# Altium schematic sheet CPLD.SchDoc(CPLD)
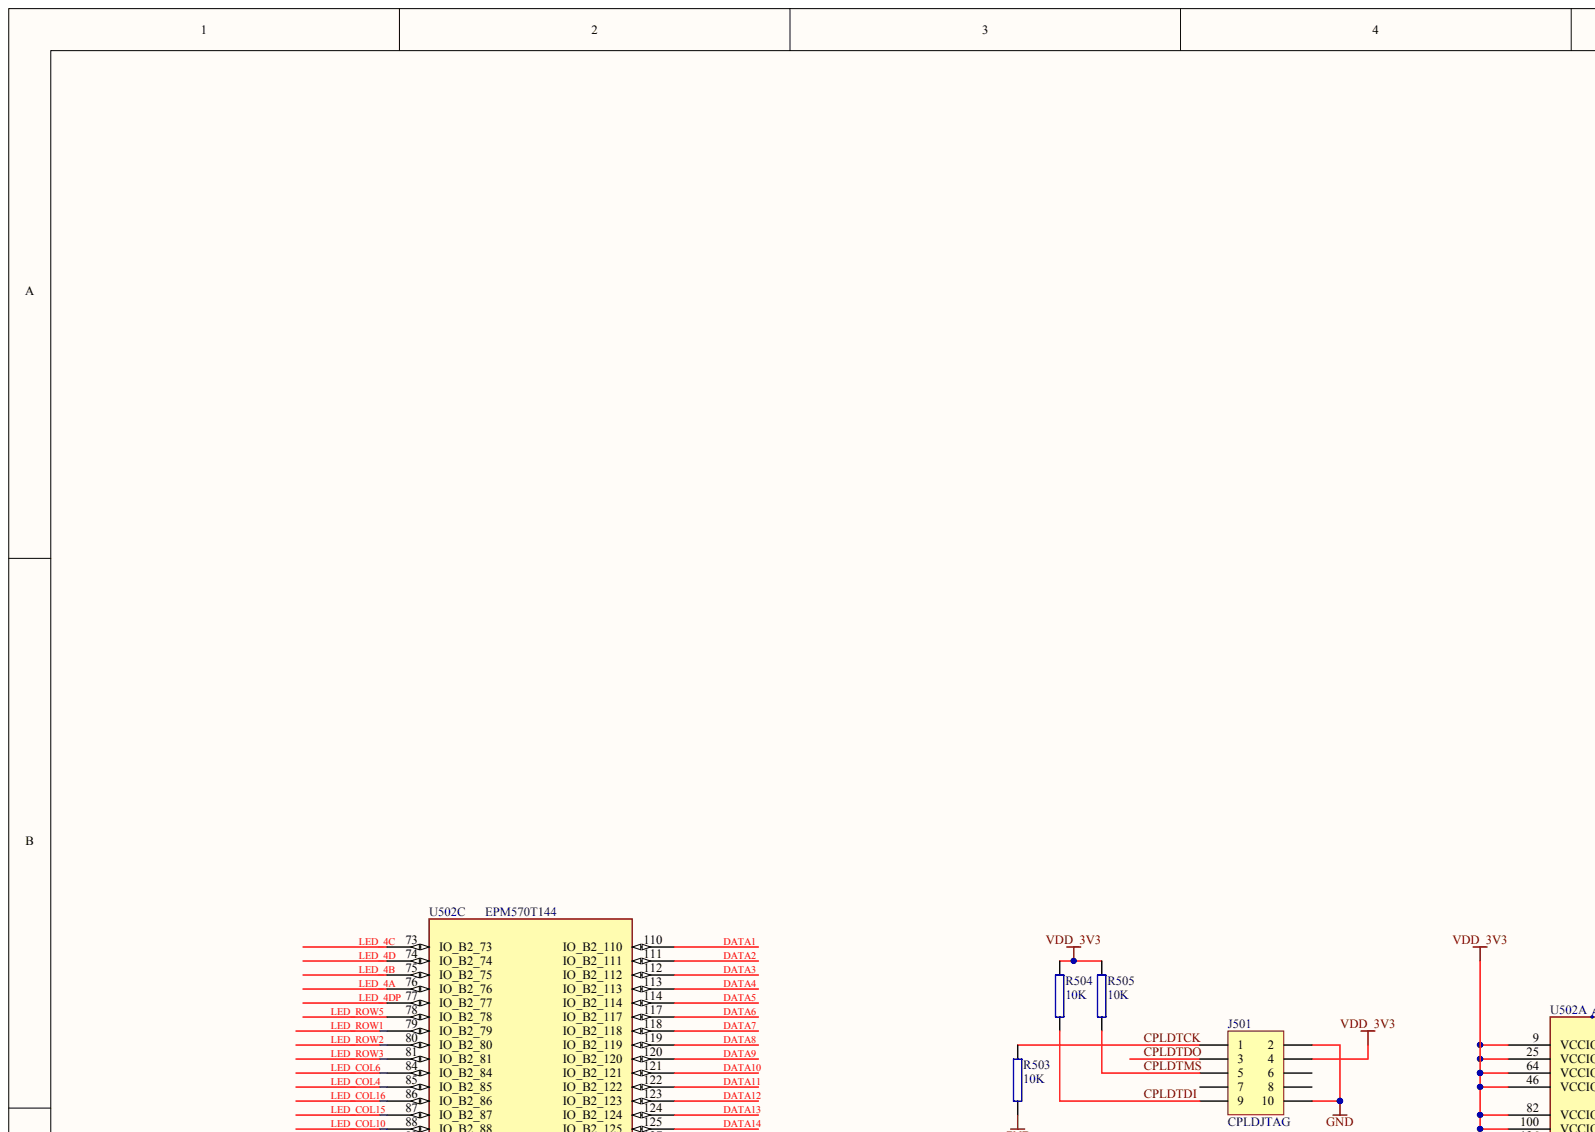
[diagram p0: CPLD.SchDoc, top left]
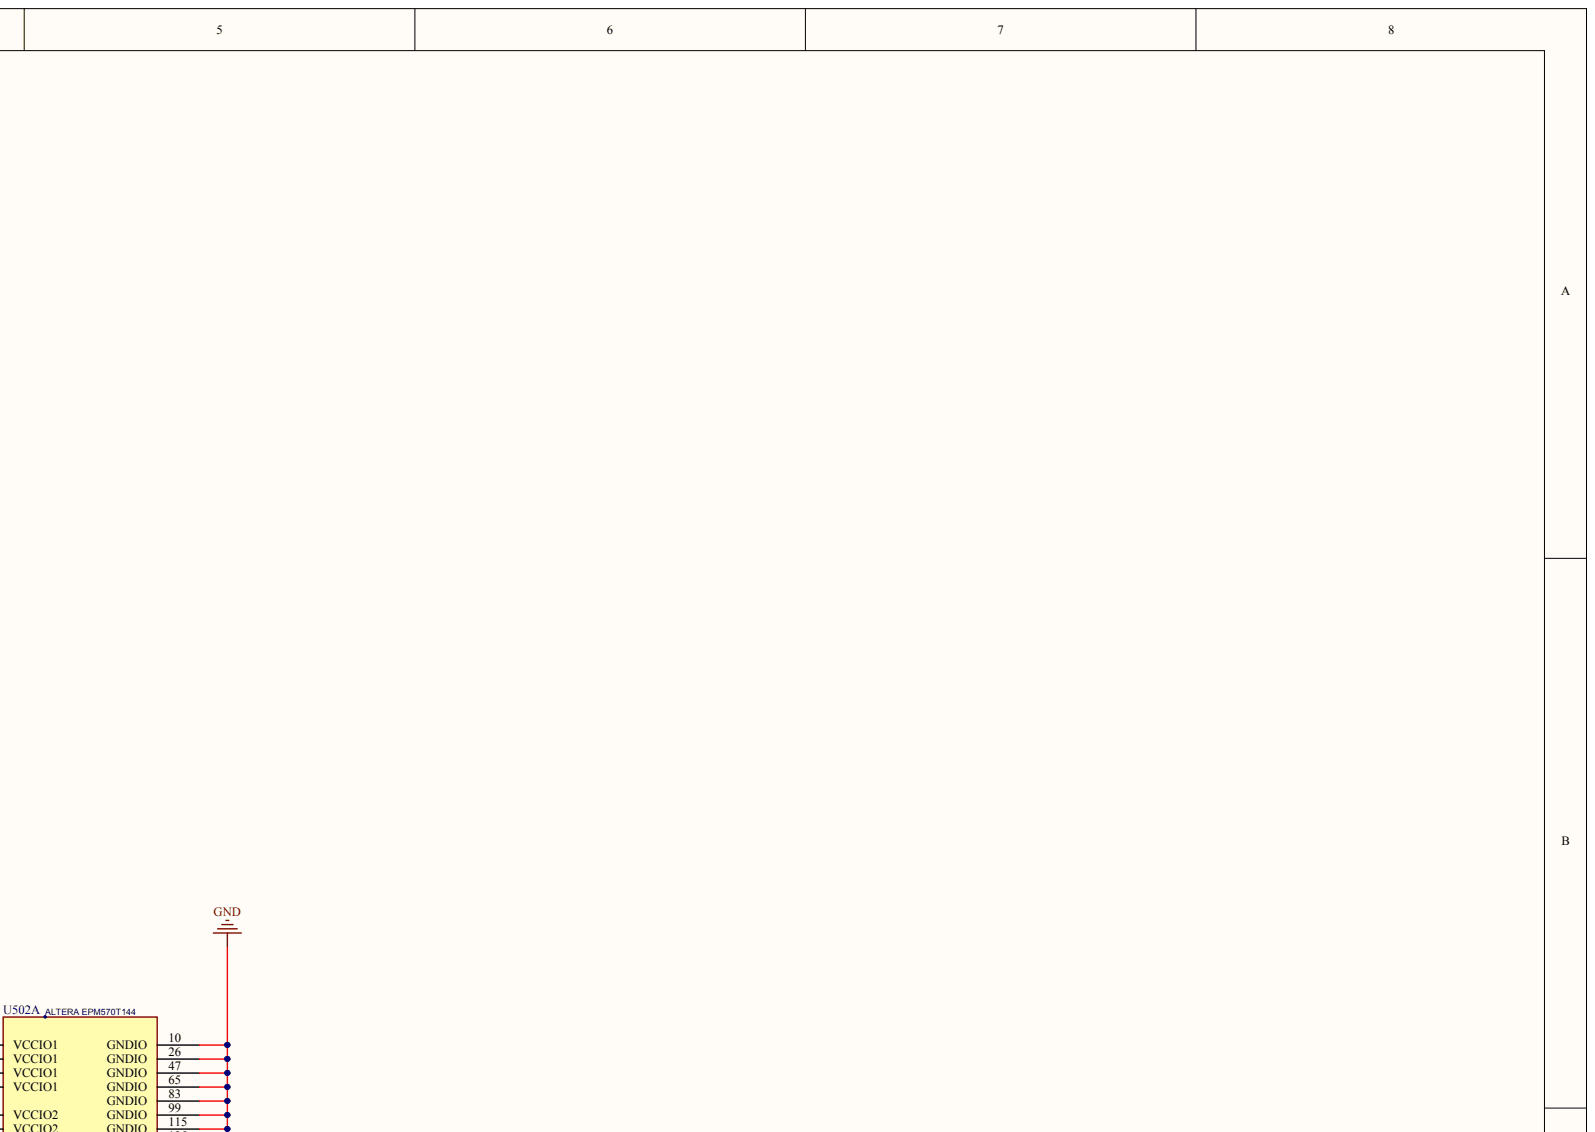
[diagram p1: CPLD.SchDoc, top right]
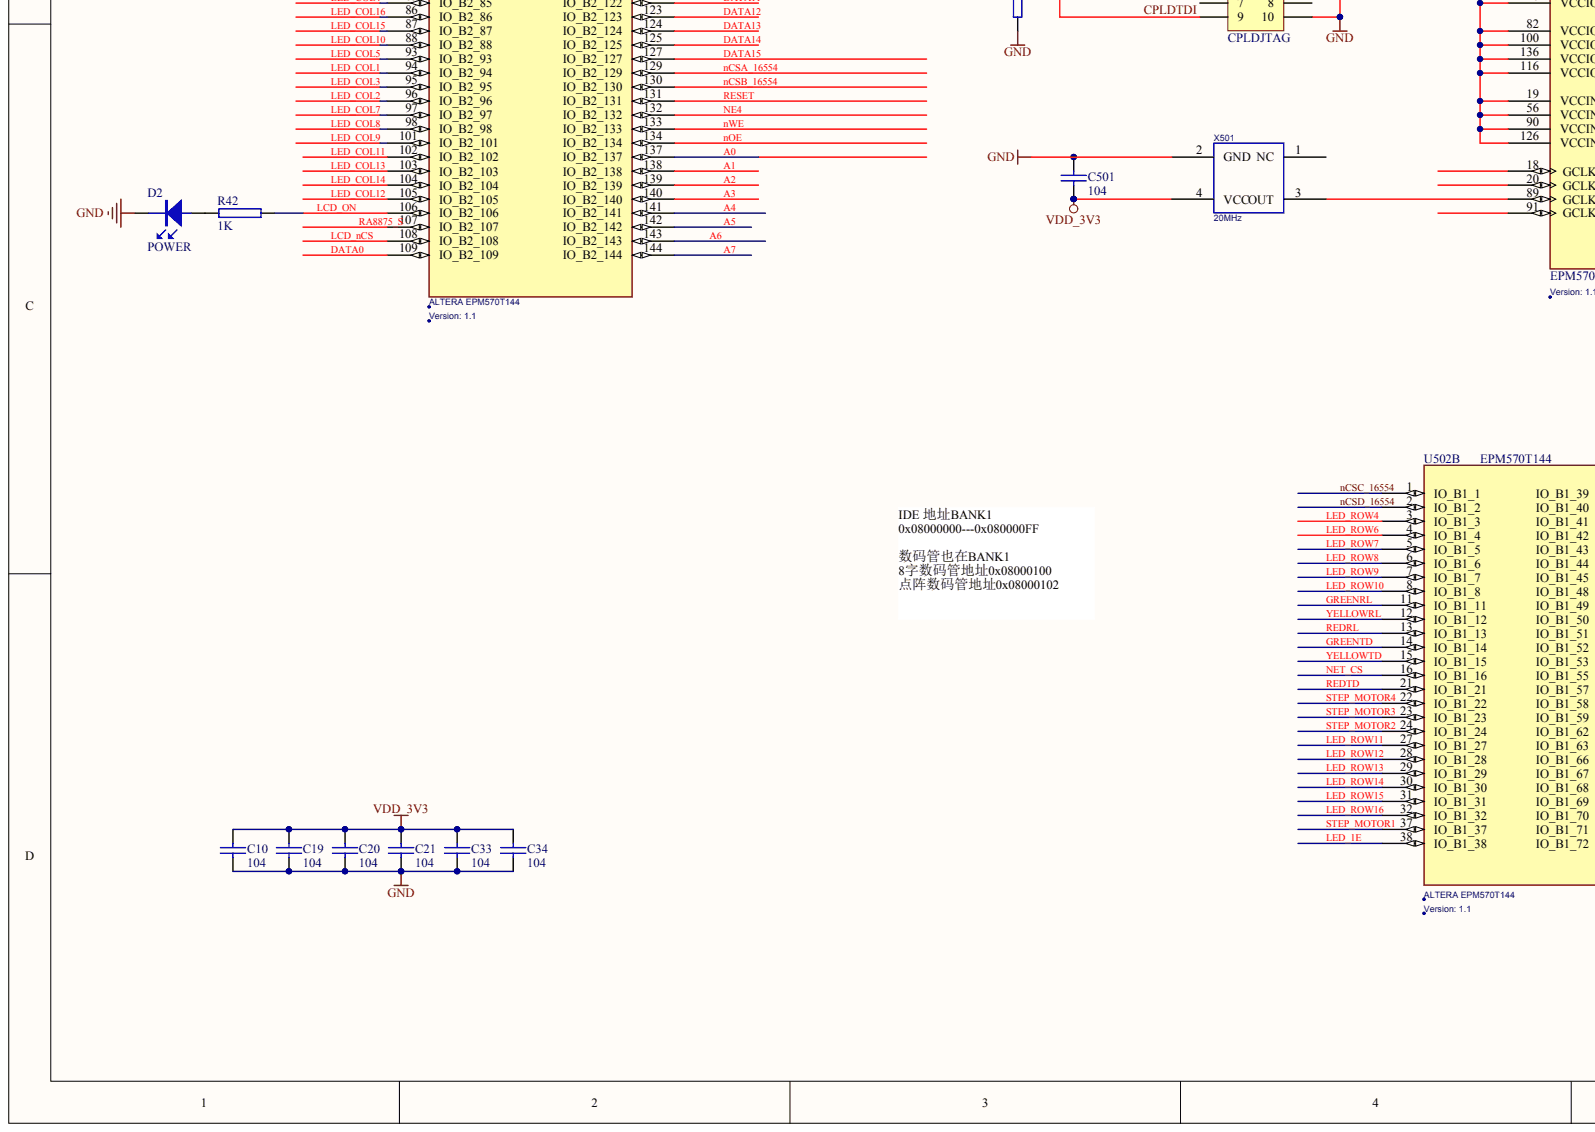
[diagram p2: CPLD.SchDoc, bottom left]
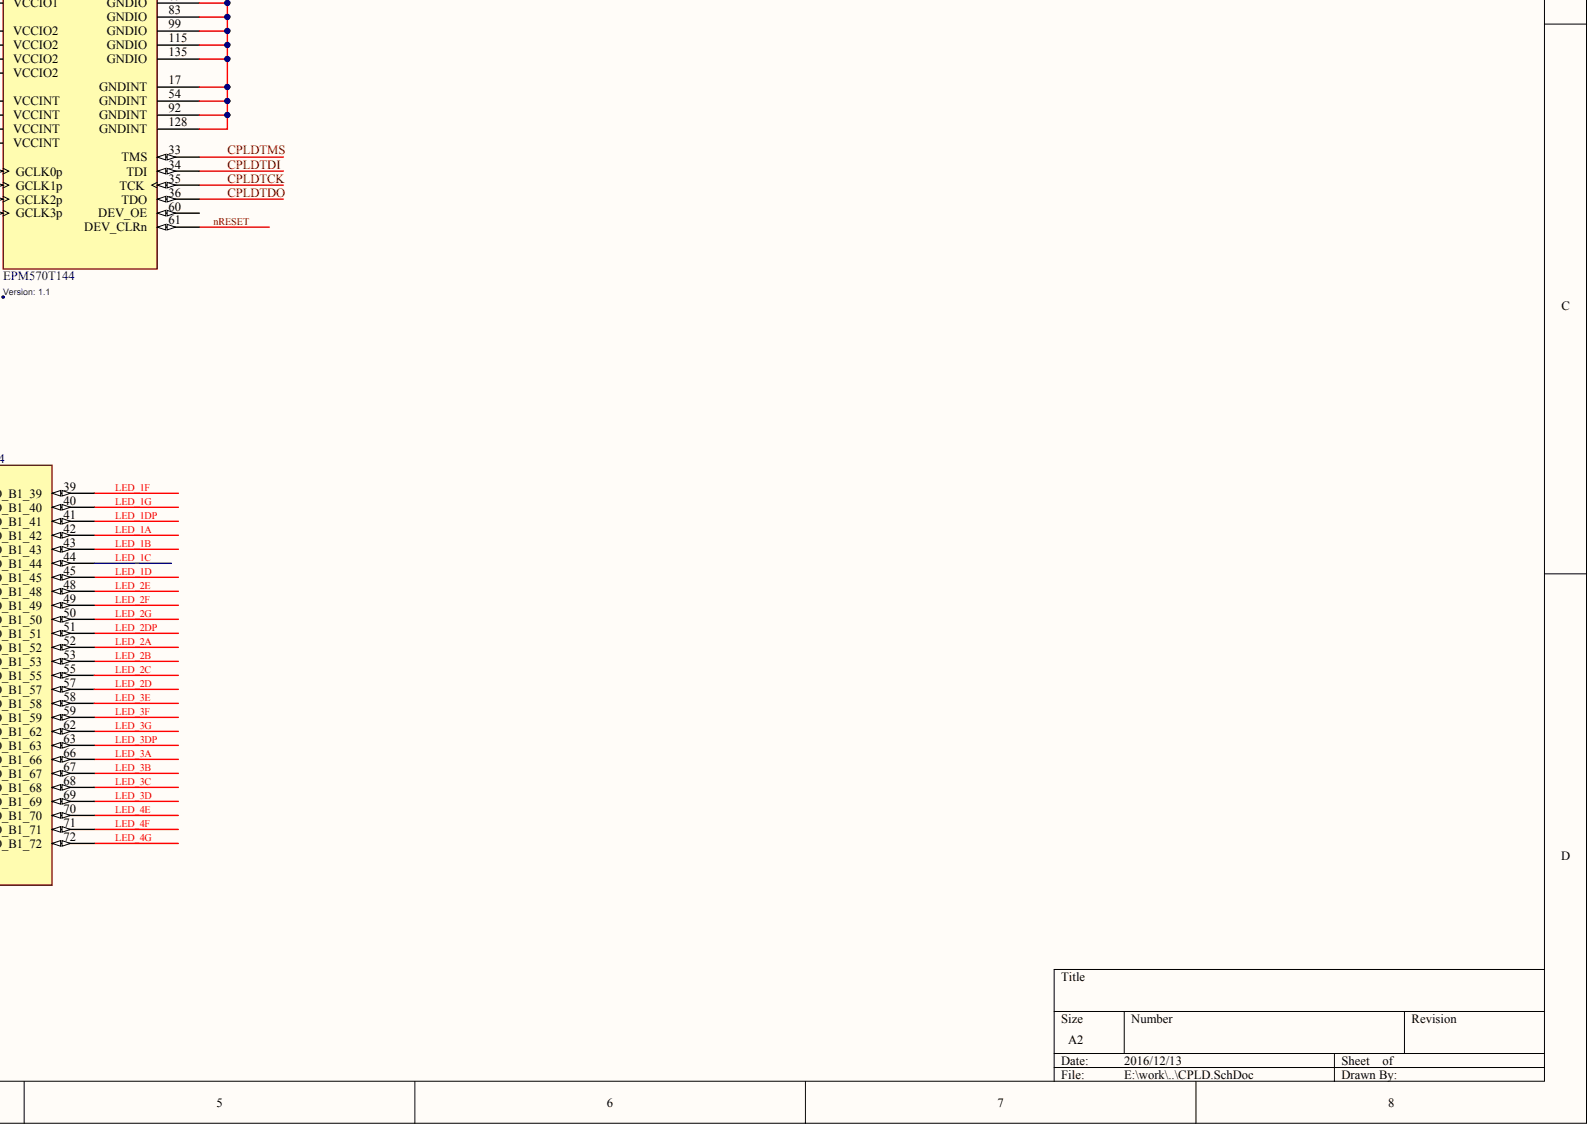
[diagram p3: CPLD.SchDoc, bottom right]

## Parts
C10  104
C19  104
C20  104
C21  104
C33  104
C34  104
C501  104
D2  POWER
J501  CPLDJTAG
R42  1K
R503  10K
R504  10K
R505  10K
U502  EPM570T144  Device: ALTERA EPM570T144  Version: 1.1  (parts A,B,C)
X501  20MHz

## Nets
GND: C10.2 C19.2 C20.2 C21.2 C33.2 C34.2 C501.1 D2.2 J501.2 J501.10 R503.1 U502.10(GNDIO) U502.17(GNDINT) U502.26(GNDIO) U502.47(GNDIO) U502.54(GNDINT) U502.65(GNDIO) U502.83(GNDIO) U502.92(GNDINT) U502.99(GNDIO) U502.115(GNDIO) U502.128(GNDINT) U502.135(GNDIO) X501.2(GND)
VDD_3V3: C10.1 C19.1 C20.1 C21.1 C33.1 C34.1 C501.2 J501.4 R504.1 R505.1 U502.9(VCCIO1) U502.19(VCCINT) U502.25(VCCIO1) U502.46(VCCIO1) U502.56(VCCINT) U502.64(VCCIO1) U502.82(VCCIO2) U502.90(VCCINT) U502.100(VCCIO2) U502.116(VCCIO2) U502.126(VCCINT) U502.136(VCCIO2) X501.4(VCC)
A0: U502.137(IO_B2_137)
A1: U502.138(IO_B2_138)
A2: U502.139(IO_B2_139)
A3: U502.140(IO_B2_140)
A4: U502.141(IO_B2_141)
A5: U502.142(IO_B2_142)
A6: U502.143(IO_B2_143)
A7: U502.144(IO_B2_144)
CPLDTCK: J501.1 R503.2 U502.35(TCK)
CPLDTDI: J501.9 R504.2 U502.34(TDI)
CPLDTDO: J501.3 U502.36(TDO)
CPLDTMS: J501.5 R505.2 U502.33(TMS)
DATA0: U502.109(IO_B2_109)
DATA1: U502.110(IO_B2_110)
DATA2: U502.111(IO_B2_111)
DATA3: U502.112(IO_B2_112)
DATA4: U502.113(IO_B2_113)
DATA5: U502.114(IO_B2_114)
DATA6: U502.117(IO_B2_117)
DATA7: U502.118(IO_B2_118)
DATA8: U502.119(IO_B2_119)
DATA9: U502.120(IO_B2_120)
DATA10: U502.121(IO_B2_121)
DATA11: U502.122(IO_B2_122)
DATA12: U502.123(IO_B2_123)
DATA13: U502.124(IO_B2_124)
DATA14: U502.125(IO_B2_125)
DATA15: U502.127(IO_B2_127)
GREENRL: U502.11(IO_B1_11)
GREENTD: U502.14(IO_B1_14)
LCD_NCS: U502.108(IO_B2_108)
LCD_ON: R42.2 U502.106(IO_B2_106)
LED_1A: U502.42(IO_B1_42)
LED_1B: U502.43(IO_B1_43)
LED_1C: U502.44(IO_B1_44)
LED_1D: U502.45(IO_B1_45)
LED_1DP: U502.41(IO_B1_41)
LED_1E: U502.38(IO_B1_38)
LED_1F: U502.39(IO_B1_39)
LED_1G: U502.40(IO_B1_40)
LED_2A: U502.52(IO_B1_52)
LED_2B: U502.53(IO_B1_53)
LED_2C: U502.55(IO_B1_55)
LED_2D: U502.57(IO_B1_57)
LED_2DP: U502.51(IO_B1_51)
LED_2E: U502.48(IO_B1_48)
LED_2F: U502.49(IO_B1_49)
LED_2G: U502.50(IO_B1_50)
LED_3A: U502.66(IO_B1_66)
LED_3B: U502.67(IO_B1_67)
LED_3C: U502.68(IO_B1_68)
LED_3D: U502.69(IO_B1_69)
LED_3DP: U502.63(IO_B1_63)
LED_3E: U502.58(IO_B1_58)
LED_3F: U502.59(IO_B1_59)
LED_3G: U502.62(IO_B1_62)
LED_4A: U502.76(IO_B2_76)
LED_4B: U502.75(IO_B2_75)
LED_4C: U502.73(IO_B2_73)
LED_4D: U502.74(IO_B2_74)
LED_4DP: U502.77(IO_B2_77)
LED_4E: U502.70(IO_B1_70)
LED_4F: U502.71(IO_B1_71)
LED_4G: U502.72(IO_B1_72)
LED_COL1: U502.94(IO_B2_94)
LED_COL2: U502.96(IO_B2_96)
LED_COL3: U502.95(IO_B2_95)
LED_COL4: U502.85(IO_B2_85)
LED_COL5: U502.93(IO_B2_93)
LED_COL6: U502.84(IO_B2_84)
LED_COL7: U502.97(IO_B2_97)
LED_COL8: U502.98(IO_B2_98)
LED_COL9: U502.101(IO_B2_101)
LED_COL10: U502.88(IO_B2_88)
LED_COL11: U502.102(IO_B2_102)
LED_COL12: U502.105(IO_B2_105)
LED_COL13: U502.103(IO_B2_103)
LED_COL14: U502.104(IO_B2_104)
LED_COL15: U502.87(IO_B2_87)
LED_COL16: U502.86(IO_B2_86)
LED_ROW1: U502.79(IO_B2_79)
LED_ROW2: U502.80(IO_B2_80)
LED_ROW3: U502.81(IO_B2_81)
LED_ROW4: U502.3(IO_B1_3)
LED_ROW5: U502.78(IO_B2_78)
LED_ROW6: U502.4(IO_B1_4)
LED_ROW7: U502.5(IO_B1_5)
LED_ROW8: U502.6(IO_B1_6)
LED_ROW9: U502.7(IO_B1_7)
LED_ROW10: U502.8(IO_B1_8)
LED_ROW11: U502.27(IO_B1_27)
LED_ROW12: U502.28(IO_B1_28)
LED_ROW13: U502.29(IO_B1_29)
LED_ROW14: U502.30(IO_B1_30)
LED_ROW15: U502.31(IO_B1_31)
LED_ROW16: U502.32(IO_B1_32)
NCSA_16554: U502.129(IO_B2_129)
NCSB_16554: U502.130(IO_B2_130)
NCSC_16554: U502.1(IO_B1_1)
NCSD_16554: U502.2(IO_B1_2)
NE4: U502.132(IO_B2_132)
NET_CS: U502.16(IO_B1_16)
NOE: U502.134(IO_B2_134)
NRESET: U502.61(DEV_CLRn)
NWE: U502.133(IO_B2_133)
RA8875_S: U502.107(IO_B2_107)
REDRL: U502.13(IO_B1_13)
REDTD: U502.21(IO_B1_21)
RESET: U502.131(IO_B2_131)
STEP_MOTOR1: U502.37(IO_B1_37)
STEP_MOTOR2: U502.24(IO_B1_24)
STEP_MOTOR3: U502.23(IO_B1_23)
STEP_MOTOR4: U502.22(IO_B1_22)
YELLOWRL: U502.12(IO_B1_12)
YELLOWTD: U502.15(IO_B1_15)
NetD2_1: D2.1 R42.1
NetU502_89: U502.89(GCLK2p) X501.3(OUT)

Not connected: J501 6 7 8; U502 18(GCLK0p) 20(GCLK1p) 60(DEV_OE) 91(GCLK3p); X501 1(NC)

## Library data of the parts
J501 = (no symbol name): Manufacturer: Generic Components; Class: Connector
X501 = (no symbol name): Right: 50; Bottom: 50
C10 C19-C21 C33 C34 C501 D2 R42 R503-R505 U502 = (no symbol name)
  R503-R505: Part Field 1: 08/06/2000; Part Field 2: Altium Hobart Technology Centre; Part Field 3: Generic Components; Part Field 4: Passive; Part Field 5: Resistor; Part Field 6: 1K
  U502: Date: May 10 2005
